# Altium schematic sheet UBX_NORA_B1_SCHEM_0002_Power.SchDoc
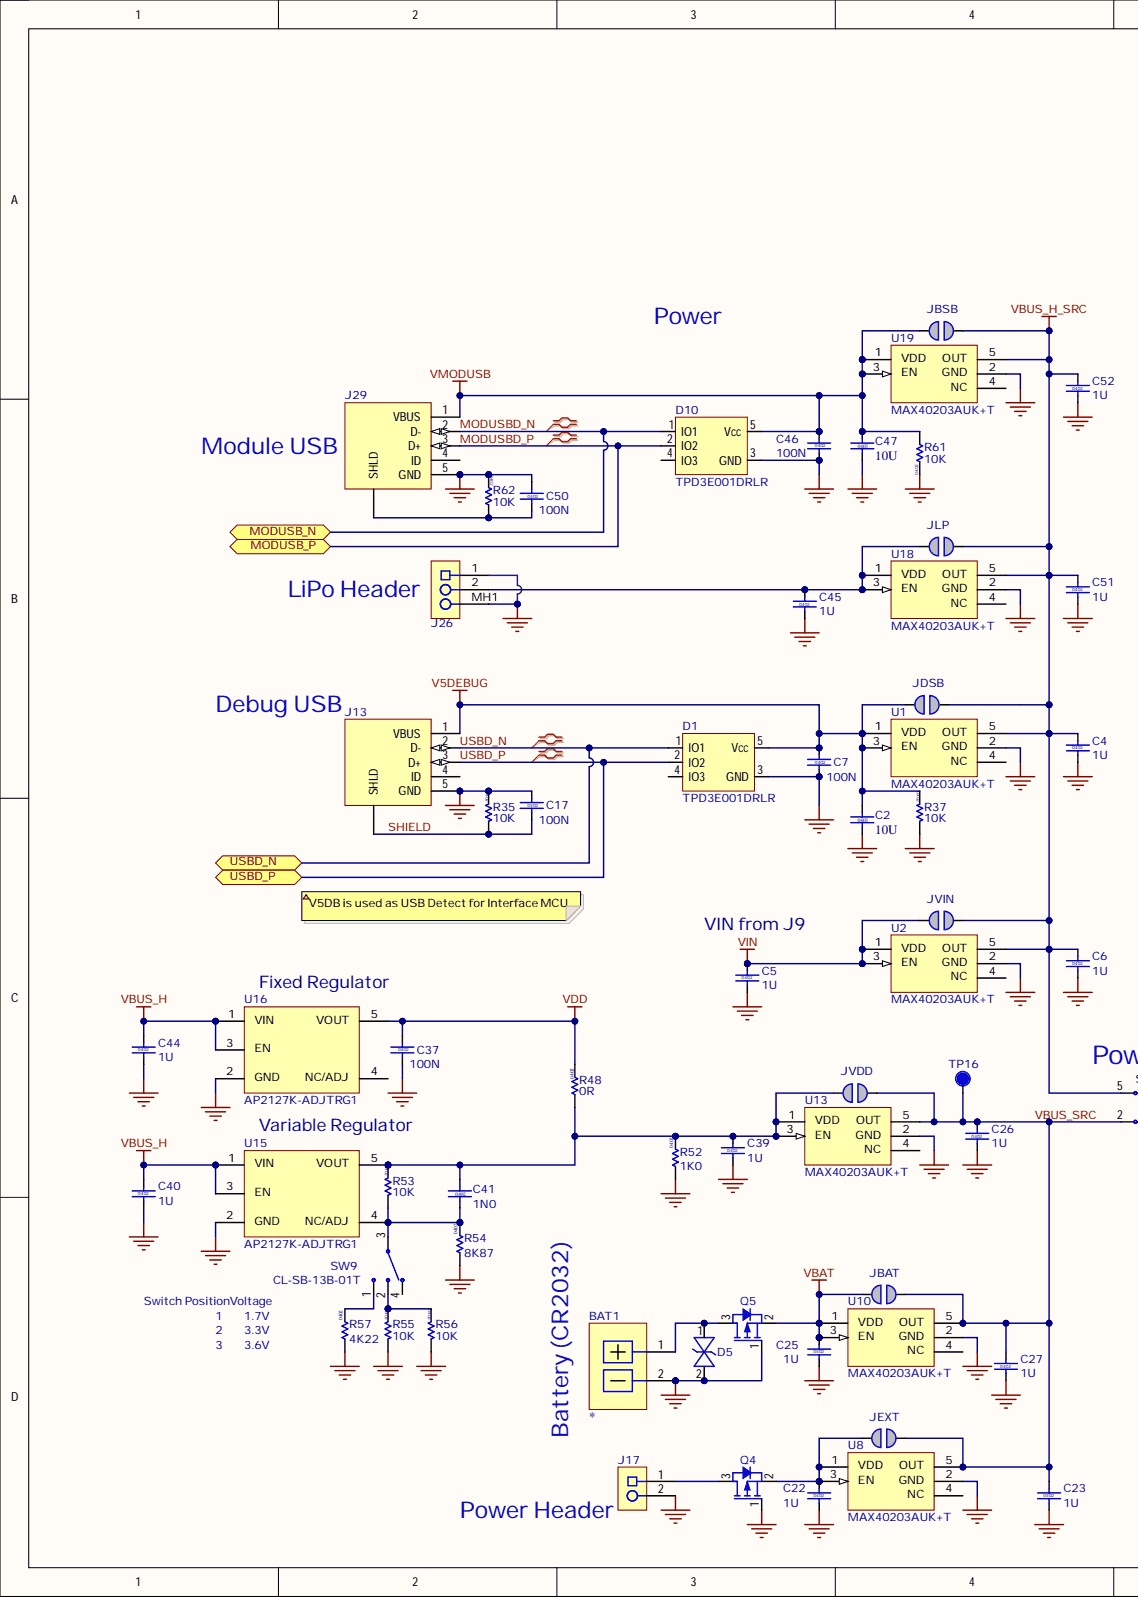
[diagram p0: UBX_NORA_B1_SCHEM_0002_Power.SchDoc, left]
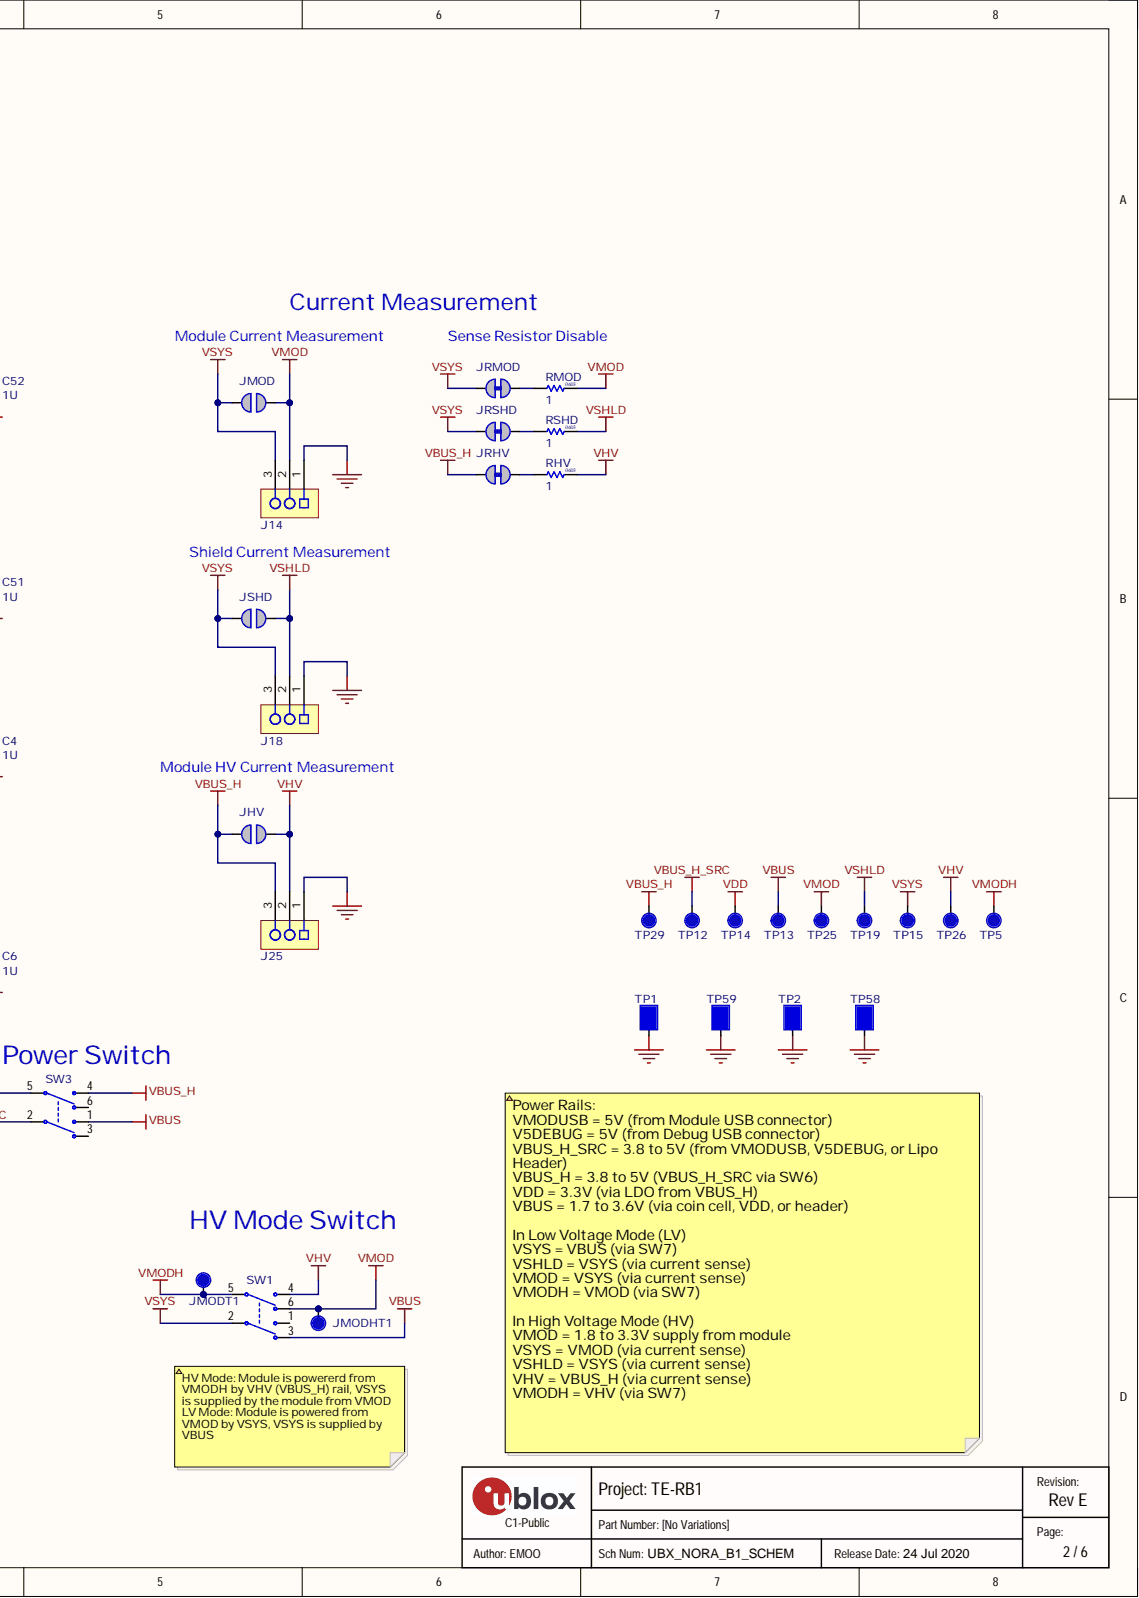
[diagram p1: UBX_NORA_B1_SCHEM_0002_Power.SchDoc, right]

## Parts
BAT1  Part Type: Battery  [Battery_S8211-46R_large]  (hidden comment: UBXH03-0000010)
C2  value 10U  Size: 0603  [CAP 0603 HD]  (hidden comment: UBXH01-000004010U)
C4  value 1U  Size: 0402  [Cap 0402 HD]  (hidden comment: UBXH01-00002481U)
C5  value 1U  Size: 0402  [Cap 0402 HD]  (hidden comment: UBXH01-00002481U)
C6  value 1U  Size: 0402  [Cap 0402 HD]  (hidden comment: UBXH01-00002481U)
C7  value 100N  Size: 0402  [Cap 0402 HD]  (hidden comment: UBXH01-2021002100N)
C17  value 100N  Size: 0402  [Cap 0402 HD]  (hidden comment: UBXH01-2021002100N)
C22  value 1U  Size: 0402  [Cap 0402 HD]  (hidden comment: UBXH01-00002481U)
C23  value 1U  Size: 0402  [Cap 0402 HD]  (hidden comment: UBXH01-00002481U)
C25  value 1U  Size: 0402  [Cap 0402 HD]  (hidden comment: UBXH01-00002481U)
C26  value 1U  Size: 0402  [Cap 0402 HD]  (hidden comment: UBXH01-00002481U)
C27  value 1U  Size: 0402  [Cap 0402 HD]  (hidden comment: UBXH01-00002481U)
C37  value 100N  Size: 0402  [Cap 0402 HD]  (hidden comment: UBXH01-2021002100N)
C39  value 1U  Size: 0402  [Cap 0402 HD]  (hidden comment: UBXH01-00002481U)
C40  value 1U  Size: 0402  [Cap 0402 HD]  (hidden comment: UBXH01-00002481U)
C41  value 1N0  Size: 0402  [Cap 0402 HD]  (hidden comment: UBXH01-00000131N0)
C44  value 1U  Size: 0402  [Cap 0402 HD]  (hidden comment: UBXH01-00002481U)
C45  value 1U  Size: 0402  [Cap 0402 HD]  (hidden comment: UBXH01-00002481U)
C46  value 100N  Size: 0402  [Cap 0402 HD]  (hidden comment: UBXH01-2021002100N)
C47  value 10U  Size: 0603  [CAP 0603 HD]  (hidden comment: UBXH01-000004010U)
C50  value 100N  Size: 0402  [Cap 0402 HD]  (hidden comment: UBXH01-2021002100N)
C51  value 1U  Size: 0402  [Cap 0402 HD]  (hidden comment: UBXH01-00002481U)
C52  value 1U  Size: 0402  [Cap 0402 HD]  (hidden comment: UBXH01-00002481U)
D1  value TPD3E001DRLR  Manufacturer P/N: TPD3E001DRLR  [SOT-553]  (hidden comment: UBXH07-0000086)
D5  UBXH07-0000034  [SOD-923]  (value not drawn)
D10  value TPD3E001DRLR  Manufacturer P/N: TPD3E001DRLR  [SOT-553]  (hidden comment: UBXH07-0000086)
J13  UBXH04-0000804  [USB_MICRO_RA_W-2TABS]  (value not drawn)
J14  UBXH04-0000792  [HDR-0.1P-3Pin-1Row-SMT]  (value not drawn)
J17  UBXH04-0000026  [HDR-0.1P-2PIN-TH]  (value not drawn)
J18  UBXH04-0000792  [HDR-0.1P-3Pin-1Row-SMT]  (value not drawn)
J25  UBXH04-0000792  [HDR-0.1P-3Pin-1Row-SMT]  (value not drawn)
J26  UBXH04-0000791  [B2B-PH-SM4-TB]  (value not drawn)
J29  UBXH04-0000804  [USB_MICRO_RA_W-2TABS]  (value not drawn)
JBAT  UBXH00-0000082  [Solderbridge-Open]  (value not drawn)  (no BOM)
JBSB  UBXH00-0000082  [Solderbridge-Open]  (value not drawn)  (no BOM)
JDSB  UBXH00-0000082  [Solderbridge-Open]  (value not drawn)  (no BOM)
JEXT  UBXH00-0000082  [Solderbridge-Open]  (value not drawn)  (no BOM)
JHV  UBXH00-0000082  [Solderbridge-Open]  (value not drawn)  (no BOM)
JLP  UBXH00-0000082  [Solderbridge-Open]  (value not drawn)  (no BOM)
JMOD  UBXH00-0000082  [Solderbridge-Open]  (value not drawn)  (no BOM)
JMODHT1  TP  [TP10R]  (value not drawn)  (no BOM)
JMODT1  TP  [TP10R]  (value not drawn)  (no BOM)
JRHV  UBXH00-0000084  [Solderbridge-Short]  (value not drawn)
JRMOD  UBXH00-0000084  [Solderbridge-Short]  (value not drawn)
JRSHD  UBXH00-0000084  [Solderbridge-Short]  (value not drawn)
JSHD  UBXH00-0000082  [Solderbridge-Open]  (value not drawn)  (no BOM)
JVDD  UBXH00-0000082  [Solderbridge-Open]  (value not drawn)  (no BOM)
JVIN  UBXH00-0000082  [Solderbridge-Open]  (value not drawn)  (no BOM)
Q4  UBXH20-0000087  [SOT-523]  (value not drawn)
Q5  UBXH20-0000087  [SOT-523]  (value not drawn)
R35  value 10K  Size: 0402  [RES 0402 HD]  (hidden comment: UBXH18-000006510K)
R37  value 10K  Size: 0402  [RES 0402 HD]  (hidden comment: UBXH18-000006510K)
R48  value 0R  Size: 0402  [RES 0402 HD]  (hidden comment: UBXH18-00030020R)
R52  value 1K0  Size: 0402  [RES 0402 HD]  (hidden comment: UBXH18-00080491K0)
R53  value 10K  Size: 0402  [RES 0402 HD]  (hidden comment: UBXH18-000006510K)
R54  value 8K87  Size: 0402  [RES 0402 HD]  (hidden comment: UBXH18-00005688K87)
R55  value 10K  Size: 0402  [RES 0402 HD]  (hidden comment: UBXH18-000006510K)
R56  value 10K  Size: 0402  [RES 0402 HD]  (hidden comment: UBXH18-000006510K)
R57  value 4K22  Size: 0402  [RES 0402 HD]  (hidden comment: UBXH18-00005694K22)
R61  value 10K  Size: 0402  [RES 0402 HD]  (hidden comment: UBXH18-000006510K)
R62  value 10K  Size: 0402  [RES 0402 HD]  (hidden comment: UBXH18-000006510K)
RHV  value 1  Size: 0603  [RES_0603_1700Lx950Wx600H_600x1000Pad_800G_FLX]  (hidden comment: UBXH18-00005551)
RMOD  value 1  Size: 0603  [RES_0603_1700Lx950Wx600H_600x1000Pad_800G_FLX]  (hidden comment: UBXH18-00005551)
RSHD  value 1  Size: 0603  [RES_0603_1700Lx950Wx600H_600x1000Pad_800G_FLX]  (hidden comment: UBXH18-00005551)
SW1  UBXH19-0000050  [SW_Slide_DPDT_JS202011SCQN]  (value not drawn)
SW3  Part Type: Switch  [SW_Slide_DPDT_JS202011SCQN]  (hidden comment: UBXH19-0000050)
SW9  value CL-SB-13B-01T  [CL-SB-13B-01T]  (hidden comment: UBXH19-0000039)
TP1  UBXH00-0000097  [TP4.5x3Sq]  (value not drawn)  (no BOM)
TP2  UBXH00-0000097  [TP4.5x3Sq]  (value not drawn)  (no BOM)
TP5  TP  [TP12R]  (no BOM)
TP12  TP  [TP12R]  (no BOM)
TP13  TP  [TP12R]  (no BOM)
TP14  TP  [TP12R]  (no BOM)
TP15  TP  [TP12R]
TP16  TP  [TP10R]  (no BOM)
TP19  TP  [TP12R]  (no BOM)
TP25  TP  [TP12R]  (no BOM)
TP26  TP  [TP12R]  (no BOM)
TP29  TP  [TP12R]  (no BOM)
TP58  UBXH00-0000097  [TP4.5x3Sq]  (value not drawn)  (no BOM)
TP59  UBXH00-0000097  [TP4.5x3Sq]  (value not drawn)  (no BOM)
U1  value MAX40203AUK+T  Manufacturer P/N: MAX40203AUK+T  [SOT-23-5_2]  (hidden comment: UBXH12-0000539)
U2  value MAX40203AUK+T  Manufacturer P/N: MAX40203AUK+T  [SOT-23-5_2]  (hidden comment: UBXH12-0000539)
U8  value MAX40203AUK+T  Manufacturer P/N: MAX40203AUK+T  [SOT-23-5_2]  (hidden comment: UBXH12-0000539)
U10  value MAX40203AUK+T  Manufacturer P/N: MAX40203AUK+T  [SOT-23-5_2]  (hidden comment: UBXH12-0000539)
U13  value MAX40203AUK+T  Manufacturer P/N: MAX40203AUK+T  [SOT-23-5_2]  (hidden comment: UBXH12-0000539)
U15  value AP2127K-ADJTRG1  Manufacturer P/N: AP2127K-ADJTRG1  Part Type: Regulator  [SOT-23-5_3D_Body_Fix]  (hidden comment: UBXH12-0000547)
U16  Manufacturer Part Number 1: AP2127K-ADJTRG1  Part Type: Regulator  [SOT-23-5_3D_Body_Fix]  (hidden comment: UBXH12-0000546)
U18  value MAX40203AUK+T  Manufacturer P/N: MAX40203AUK+T  [SOT-23-5_2]  (hidden comment: UBXH12-0000539)
U19  value MAX40203AUK+T  Manufacturer P/N: MAX40203AUK+T  [SOT-23-5_2]  (hidden comment: UBXH12-0000539)

## Ports
MODUSB_N, MODUSB_P, USBD_N, USBD_P

## Nets
GND: BAT1.2 C2.2 C4.2 C5.2 C6.2 C7.2 C17.1 C22.2 C23.2 C25.2 C26.2 C27.2 C37.2 C39.2 C40.2 C44.2 C45.2 C46.2 C47.2 C50.1 C51.2 C52.2 D1.3(GND) D5.2 D10.3(GND) J13.5(GND) J14.1 J17.2 J18.1 J25.1 J26.1 J26.MH1 J29.5(GND) Q4.1 Q5.1 R35.2 R37.1 R52.1 R54.1 R55.1 R56.1 R57.1 R61.2 R62.2 TP1.1 TP2.1 TP58.1 TP59.1 U1.2(GND) U2.2(GND) U8.2(GND) U10.2(GND) U13.2(GND) U15.2(GND) U16.2(GND) U18.2(GND) U19.2(GND)
V5DEBUG: C2.1 C7.1 D1.5(Vcc) J13.1(VBUS) JDSB.2 R37.2 U1.1(VDD) U1.3(EN)
VBAT: C25.1 JBAT.2 Q5.2 U10.1(VDD) U10.3(EN)
VBUS: SW1.3 SW3.1 TP13.1
VBUS_H: C40.1 C44.1 J25.3 JHV.2 JRHV.2 SW3.4 TP29.1 U15.1(VIN) U15.3(EN) U16.1(VIN) U16.3(EN)
VBUS_H_SRC: C4.1 C6.1 C51.1 C52.1 JBSB.1 JDSB.1 JLP.1 JVIN.1 SW3.5 TP12.1 U1.5(OUT) U2.5(OUT) U18.5(OUT) U19.5(OUT)
VDD: C37.1 R48.2 TP14.1 U16.5(VOUT)
VHV: J25.2 JHV.1 RHV.2 SW1.4 TP26.1
VIN: C5.1 JVIN.2 U2.1(VDD) U2.3(EN)
VMOD: J14.2 JMOD.1 JMODHT1.1 RMOD.2 SW1.6 TP25.1
VMODH: JMODT1.1 SW1.5 TP5.1
VMODUSB: C46.1 C47.1 D10.5(Vcc) J29.1(VBUS) JBSB.2 R61.1 U19.1(VDD) U19.3(EN)
VSHLD: J18.2 JSHD.1 RSHD.2 TP19.1
VSYS: J14.3 J18.3 JMOD.2 JRMOD.2 JRSHD.2 JSHD.2 SW1.2 TP15.1
MODUSBD_N: D10.1(IO1) J29.2(D-) ; port MODUSB_N
MODUSBD_P: D10.2(IO2) J29.3(D+) ; port MODUSB_P
SHIELD: C17.2 J13.6(SHLD) R35.1
USBD_N: D1.1(IO1) J13.2(D-) ; port USBD_N
USBD_P: D1.2(IO2) J13.3(D+) ; port USBD_P
VBUS_SRC: C23.1 C26.1 C27.1 JBAT.1 JEXT.1 JVDD.1 SW3.2 TP16.1 U8.5(OUT) U10.5(OUT) U13.5(OUT)
NetBAT1_1: BAT1.1 D5.1 Q5.3
NetC22_1: C22.1 JEXT.2 Q4.2 U8.1(VDD) U8.3(EN)
NetC39_1: C39.1 C41.1 JVDD.2 R48.1 R52.2 R53.2 U13.1(VDD) U13.3(EN) U15.5(VOUT)
NetC41_2: C41.2 R53.1 R54.2 SW9.3 U15.4(NC/ADJ)
NetC45_1: C45.1 J26.2 JLP.2 U18.1(VDD) U18.3(EN)
NetC50_2: C50.2 J29.6(SHLD) R62.1
NetJ17_1: J17.1 Q4.3
NetJRHV_1: JRHV.1 RHV.1
NetJRMOD_1: JRMOD.1 RMOD.1
NetJRSHD_1: JRSHD.1 RSHD.1
NetR55_2: R55.2 R56.2 SW9.2
NetR57_2: R57.2 SW9.1

Not connected: D1 4(IO3); D10 4(IO3); J13 4(ID); J29 4(ID); SW1 1; SW3 3 6; SW9 4; U1 4(NC); U2 4(NC); U8 4(NC); U10 4(NC); U13 4(NC); U16 4(NC/ADJ); U18 4(NC); U19 4(NC)

## Library data of the parts
BAT1 = UBXH03-0000010: BATTERY HOLDER COIN SMT CR2016 CR2020 CR2025 CR2032 HARWIN S8211-46R; Database Table Name: Electromechanical - Battery; Editor: eric.moog; Env: ROHS; Perferred: PREF; Permitted Use: Support Products; Component Kind: Standard; Library Name: UBX_Parts_DB_Lib.DbLib; Max Operating Temp: 105°C; Min Operating Temp: -40°C; Part Number: UBXH03-0000010; RefDes: BT; UBX Wiki: https://wiki.u-blox.com/bin/view/Operations/PartDetails?index=38906
C2 C47 = UBXH01-0000040: CAP CER X5R 0603 10U 20% 10V; Manufacturer: Taiyo Yuden Company, Ltd.; Manufacturer P/N: LMK107BBJ106MALT; Database Table Name: Passive - Capacitor; Editor: pierpaolo.arban; Env: ROHS; Mfg P/N Released: Yes; Mfg P/N Split: 100; Mfg P/N Status: Active; Perferred: PREF; Permitted Use: Volume Products; Released by: matej.budin; Component Kind: Standard; Library Name: UBX_Parts_DB_Lib.DbLib; Manufacturer 2: Murata Manufacturing Company, Ltd.; Manufacturer 3: Taiyo Yuden Company, Ltd.; Manufacturer P/N 2: GRM188R61A106KAAL; Manufacturer P/N 3: LMK107BJ106MALTD; Max Voltage: 10V; Mfg P/N Datasheet: https://wiki.u-blox.com/bin/view/Generic/Docs/DocumentSummary?index=16905; Mfg P/N Datasheet 2: https://wiki.u-blox.com/bin/view/Generic/Docs/DocumentSummary?index=27232; Mfg P/N Datasheet 3: https://wiki.u-blox.com/bin/view/Generic/Docs/DocumentSummary?index=29392; Mfg P/N Released 2: Yes; Mfg P/N Released 3: Yes; Mfg P/N Split 2: 0; Mfg P/N Split 3: 0; Mfg P/N Status 2: Active; Mfg P/N Status 3: NRND; Part Number: UBXH01-0000040; Part Type: Capacitor; RefDes: C; Release Data: 2013-01-10T11:54:39; Signal Integrity: Cap Semi; Simulation: CAP; Tolerance: 20%; UBX Wiki: https://wiki.u-blox.com/bin/view/Operations/PartDetails?index=16909
C4-C6 C22 C23 C25-C27 C39 C40 C44 C45 C51 C52 = UBXH01-0000248: CAP CER X5R 0402 1U 10% 10V; Manufacturer: Murata Manufacturing Company, Ltd.; Manufacturer P/N: GRM155R61C105KA12; Database Table Name: Passive - Capacitor; Editor: michael.hein; Env: ROHS; Mfg P/N Released: Yes; Mfg P/N Split: 100; Mfg P/N Status: Active; Perferred: PREF; Permitted Use: Volume Products; Released by: igor.shevchenko; Component Kind: Standard; Library Name: UBX_Parts_DB_Lib.DbLib; Manufacturer 2: TDK Corporation; Manufacturer 3: Samsung Electro-Mechanics; Manufacturer P/N 2: C1005X5R1E105K050BC; Manufacturer P/N 3: CL05A105KA5NQNC; Max Operating Temp: 85°C; Max Voltage: 10V; Mfg P/N Datasheet: https://wiki.u-blox.com/bin/view/Generic/Docs/DocumentSummary?index=29581; Mfg P/N Datasheet 2: https://wiki.u-blox.com/bin/view/Generic/Docs/DocumentSummary?index=28058; Mfg P/N Datasheet 3: https://wiki.u-blox.com/bin/view/Generic/Docs/DocumentSummary?index=28056; Mfg P/N Released 2: Yes; Mfg P/N Released 3: Yes; Mfg P/N Split 2: 0; Mfg P/N Split 3: 0; Mfg P/N Status 2: Active; Mfg P/N Status 3: Active; Min Operating Temp: -40°C; Part Number: UBXH01-0000248; Part Type: Capacitor; RefDes: C; Release Data: 2016-03-16T11:26:35; Signal Integrity: Cap Semi; Simulation: CAP; Tolerance: 10%; UBX Wiki: https://wiki.u-blox.com/bin/view/Operations/PartDetails?index=24378
C7 C17 C37 C46 C50 = UBXH01-2021002: CAP CER X5R 0402 100N 10% 10V; Manufacturer: Murata Manufacturing Company, Ltd.; Manufacturer P/N: GRM155R61A104KA01; Database Table Name: Passive - Capacitor; Editor: roland.rust; Env: GREEN; Mfg P/N Released: Yes; Mfg P/N Split: 100; Mfg P/N Status: NRND; Perferred: PREF; Permitted Use: Volume Products; Component Kind: Standard; Library Name: UBX_Parts_DB_Lib.DbLib; Manufacturer 2: Samsung Electro-Mechanics; Manufacturer P/N 2: CL05A104KP5NNN; Max Voltage: 10V; Mfg P/N Datasheet 2: https://wiki.u-blox.com/bin/view/Generic/Docs/DocumentSummary?index=28113; Mfg P/N Released 2: Yes; Mfg P/N Split 2: 0; Mfg P/N Status 2: Active; Part Number: UBXH01-2021002; Part Type: Capacitor; RefDes: C; Release Data: 2005-07-22T13:59:44; Signal Integrity: Cap Semi; Simulation: CAP; Tolerance: 10%; UBX Wiki: https://wiki.u-blox.com/bin/view/Operations/PartDetails?index=5759; Released by: roland.rust
C41 = UBXH01-0000013: CAP CER X7R 0402 1N0 10% 50V; Manufacturer: Murata Manufacturing Company, Ltd.; Manufacturer P/N: GRM155R71H102KA01; Database Table Name: Passive - Capacitor; Editor: massimo.vlacci; Env: ROHS; Mfg P/N Released: Yes; Mfg P/N Split: 100; Mfg P/N Status: NRND; Perferred: PREF; Permitted Use: Volume Products; Released by: dario.antonaz; Component Kind: Standard; Library Name: UBX_Parts_DB_Lib.DbLib; Max Voltage: 50V; Mfg P/N Datasheet: https://wiki.u-blox.com/bin/view/Generic/Docs/DocumentSummary?index=22572; Part Number: UBXH01-0000013; Part Type: Capacitor; RefDes: C; Release Data: 2015-04-23T14:26:43; Signal Integrity: Cap Semi; Simulation: CAP; Tolerance: 10%; UBX Wiki: https://wiki.u-blox.com/bin/view/Operations/PartDetails?index=8466
D1 D10 = UBXH07-0000086: TVS DIODE 5.5VWM; Manufacturer: Texas Instruments; Database Table Name: Discrete - Diode; Editor: hozaifa.abdelgadir; Env: GREEN; Mfg P/N Released: No; Mfg P/N Split: 100; Mfg P/N Status: Active; Perferred: PREF; Permitted Use: Support Products; Component Kind: Standard; Library Name: UBX_Parts_DB_Lib.DbLib; Max Operating Temp: 85°C; Mfg P/N Datasheet: https://wiki.u-blox.com/bin/view/Generic/Docs/DocumentSummary?index=38197; Min Operating Temp: -40°C; Part Number: UBXH07-0000086; Part Type: Diode; RefDes: D; UBX Wiki: https://wiki.u-blox.com/bin/view/Operations/PartDetails?index=38374
D5 = UBXH07-0000034: ESD PROTECTION DIODE ULTRA LOW LEAKAGE SOD923 ON SEMI 3V3; Manufacturer: ON Semiconductor; Manufacturer P/N: ESD9R3.3ST5G; Database Table Name: Discrete - Diode; Editor: pierpaolo.arban; Env: ROHS; Mfg P/N Released: Yes; Mfg P/N Split: 100; Mfg P/N Status: Active; Perferred: PREF; Permitted Use: Support Products; Released by: dario.antonaz; Component Kind: Standard; Library Name: UBX_Parts_DB_Lib.DbLib; Mfg P/N Datasheet: https://wiki.u-blox.com/bin/view/Generic/Docs/DocumentSummary?index=22449; Part Number: UBXH07-0000034; Part Type: Diode; RefDes: D; Release Data: 2015-04-23T14:51:11; UBX Wiki: https://wiki.u-blox.com/bin/view/Operations/PartDetails?index=22447; Value: 3V3
J13 J29 = UBXH04-0000804: CONN RECEPTACLE USB MICRO B 5-PIN SMD TH RA 5.5V 1.8A; Manufacturer: Amphenol Commercial Products (Amphenol ICC); Manufacturer P/N: 10118193-0001LF; Database Table Name: Electromechanical - Connector; Editor: eric.moog; Env: ROHS; Mfg P/N Released: No; Mfg P/N Split: 100; Mfg P/N Status: Active; Permitted Use: Support Products; Value: 10118193-0001LF; Component Kind: Standard; Library Name: UBX_Parts_DB_Lib.DbLib; Max Current: 1.8A; Max Operating Temp: 85°C; Max Voltage: 5.5V; Mfg P/N Datasheet: https://wiki.u-blox.com/bin/view/Generic/Docs/DocumentSummary?index=38943; Min Operating Temp: -30°C; Part Number: UBXH04-0000804; Part Type: Connector; Perferred: N/A; RefDes: J; UBX Wiki: https://wiki.u-blox.com/bin/view/Operations/PartDetails?index=38944
J14 J18 J25 = UBXH04-0000792: 1-ROW SMD CONN 100MIL GRID 3 -PIN 100V 3A; Manufacturer: HARWiN; Manufacturer P/N: M20-8770342; Database Table Name: Electromechanical - Connector; Editor: eric.moog; Env: ROHS; Mfg P/N Released: No; Mfg P/N Split: 100; Mfg P/N Status: Active; Permitted Use: Support Products; Value: M20-8770342; Component Kind: Standard; Library Name: UBX_Parts_DB_Lib.DbLib; Max Current: 3A; Max Operating Temp: 105°C; Max Voltage: 100V; Mfg P/N Datasheet: https://wiki.u-blox.com/bin/view/Generic/Docs/DocumentSummary?index=28457; Min Operating Temp: -40°C; Part Number: UBXH04-0000792; Part Type: Connector; Perferred: N/A; RefDes: J; UBX Wiki: https://wiki.u-blox.com/bin/view/Operations/PartDetails?index=38569
J17 = UBXH04-0000026: 1-ROW TH-PCB SOCKETS 100MIL GRID 2-PIN 0.64MM SQUARE POLARIZE; Manufacturer: HARWiN; Manufacturer P/N: M20-9990245; Database Table Name: Electromechanical - Connector; Editor: roland.rust; Env: ROHS; Mfg P/N Released: Yes; Mfg P/N Split: 100; Mfg P/N Status: Active; Perferred: PREF; Permitted Use: Internal Use; Released by: alvaro.nussbaum; Value: M20-9990245; Component Kind: Standard; Library Name: UBX_Parts_DB_Lib.DbLib; Part Number: UBXH04-0000026; Part Type: Connector; RefDes: J; Release Data: 2015-06-04T16:45:09; UBX Wiki: https://wiki.u-blox.com/bin/view/Operations/PartDetails?index=10937
J26 = UBXH04-0000791: CONN 1-ROW SMT 2.0MM GRID 2-PIN VERT 100V 2A; Manufacturer: JST; Part Name: Conn, SMT, 0.079" Pitch, 2 Pin, Vert, Black; Database Table Name: Electromechanical - Connector; Editor: eric.moog; Env: ROHS; Item Phase: In Production; Mfg P/N Released: No; Mfg P/N Split: 100; Mfg P/N Status: Active; Permitted Use: Support Products; UBX Code: None; Use in new designs: Yes; Value: B2B-PH-SM4-TB; Component Kind: Standard; Diameter: None; Height: 6.5mm; Library Name: UBX_Parts_DB_Lib.DbLib; Manufacturer 2: None; Manufacturer P/N: B2B-PH-SM4-TB; Manufacturer Part Number 1: B2B-PH-SM4-TB; Manufacturer Part Number 2: None; Max Current: 2A; Max Operating Temp: 85°C; Max Voltage: 100V; Mfg P/N Datasheet: https://wiki.u-blox.com/bin/view/Generic/Docs/DocumentSummary?index=35463; Min Operating Temp: -30°C; Part Number: UBXH04-0000791; Part Type: Connector; Perferred: N/A; Pins: 2; RefDes: J; Revision: 0A; Supplier 1: None; Supplier 2: None; Supplier Part Number 1: None; Supplier Part Number 2: None; UBX Wiki: https://wiki.u-blox.com/bin/view/Operations/PartDetails?index=38567; Voltage: None
JBAT JBSB JDSB JEXT JHV JLP JMOD JSHD JVDD JVIN = UBXH00-0000082: SOLDERBRIDGE-OPEN; Database Table Name: Printed Circuit Element - Connector; Editor: aneeb.sohail; Env: GREEN; Perferred: PREF; Permitted Use: Volume Products; Library Name: UBX_Parts_DB_Lib.DbLib; Max Operating Temp: 155°C; Min Operating Temp: -55°C; Part Number: UBXH00-0000082; Part Type: Connector; RefDes: J; UBX Wiki: https://wiki.u-blox.com/bin/view/Operations/PartDetails?index=36361
JMODHT1 JMODT1 TP16 = UBXH00-0000096: TESTPOINT 1MM CIRCULAR; Database Table Name: Printed Circuit Element - Testpoint; Editor: eric.moog; Perferred: PREF; Permitted Use: Volume Products; Env: N/A; Library Name: UBX_Parts_DB_Lib.DbLib; Max Operating Temp: 125°C; Min Operating Temp: -55°C; Part Number: UBXH00-0000096; Part Type: Testpoint; RefDes: TP; UBX Wiki: https://wiki.u-blox.com/bin/view/Operations/PartDetails?index=38784
JRHV JRMOD JRSHD = UBXH00-0000084: SOLDERBRIDGE-SHORT; Database Table Name: Printed Circuit Element - Connector; Editor: aneeb.sohail; Env: GREEN; Perferred: PREF; Permitted Use: Volume Products; Component Kind: Net Tie; Library Name: UBX_Parts_DB_Lib.DbLib; Max Operating Temp: 155°C; Min Operating Temp: -55°C; Part Number: UBXH00-0000084; Part Type: Connector; RefDes: J; UBX Wiki: https://wiki.u-blox.com/bin/view/Operations/PartDetails?index=36363
Q4 Q5 = UBXH20-0000087: P-CHANNEL MOSFET DIODES SOT-523 DMG1013T-7 20V 460mA; Database Table Name: Discrete - Transistor; Editor: eric.moog; Env: ROHS; Perferred: PREF; Permitted Use: Volume Products; Component Kind: Standard; Library Name: UBX_Parts_DB_Lib.DbLib; Max Current: 460mA; Max Operating Temp: 155°C; Max Voltage: 20V; Min Operating Temp: -55°C; Part Number: UBXH20-0000087; Part Type: Transistor; RefDes: Q; UBX Wiki: https://wiki.u-blox.com/bin/view/Operations/PartDetails?index=38920
R35 R37 R53 R55 R56 R61 R62 = UBXH18-0000065: RES THICK FILM CHIP 0402 10K 1% 63mW; Manufacturer: KOA; Manufacturer P/N: RK73H1ETTP1002F; Database Table Name: Passive - Resistor; Editor: erian.bezhani; Env: ROHS; Mfg P/N Released: Yes; Mfg P/N Split: 100; Mfg P/N Status: Active; Perferred: PREF; Permitted Use: Volume Products; Released by: massimo.vlacci; Component Kind: Standard; Library Name: UBX_Parts_DB_Lib.DbLib; Max Power: 63mW; Mfg P/N Datasheet: https://wiki.u-blox.com/bin/view/Generic/Docs/DocumentSummary?index=8222; Part Number: UBXH18-0000065; Part Type: Resistor; RefDes: R; Release Data: 2012-06-08T18:02:21; Signal Integrity: Res; Simulation: RESISTOR; Tolerance: 1%; UBX Wiki: https://wiki.u-blox.com/bin/view/Operations/PartDetails?index=16837
R48 = UBXH18-0003002: RES THICK FILM CHIP 0402 0R 0 100mW; Manufacturer: KOA; Manufacturer P/N: RK73Z1ETTD; Database Table Name: Passive - Resistor; Editor: charlotte.biber; Env: ROHS; Mfg P/N Released: Yes; Mfg P/N Split: 100; Mfg P/N Status: NRND; Perferred: PREF; Permitted Use: Volume Products; Released by: peter.mueller; Tolerance: 0; Component Kind: Standard; Library Name: UBX_Parts_DB_Lib.DbLib; Manufacturer 2: YAGEO; Manufacturer P/N 2: 2322_705_91001_L; Max Power: 100mW; Mfg P/N Released 2: Yes; Mfg P/N Split 2: 0; Mfg P/N Status 2: NRND; Part Number: UBXH18-0003002; Part Type: Resistor; RefDes: R; Release Data: 2012-06-05T09:49:55; Signal Integrity: Res; Simulation: RESISTOR; UBX Wiki: https://wiki.u-blox.com/bin/view/Operations/PartDetails?index=6611
R52 = UBXH18-0008049: RES THICK FILM CHIP 0402 1K0 5% 100mW; Manufacturer: YAGEO; Manufacturer P/N: 2322_705_70102_L; Database Table Name: Passive - Resistor; Editor: roland.rust; Env: ROHS; Mfg P/N Released: Yes; Mfg P/N Split: 100; Mfg P/N Status: Obsolete; Perferred: PREF; Permitted Use: Volume Products; Component Kind: Standard; Library Name: UBX_Parts_DB_Lib.DbLib; Manufacturer 2: KOA; Manufacturer P/N 2: RK73B1ETTD102J; Max Power: 100mW; Mfg P/N Released 2: Yes; Mfg P/N Split 2: 0; Mfg P/N Status 2: NRND; Part Number: UBXH18-0008049; Part Type: Resistor; RefDes: R; Release Data: 2005-07-22T13:59:44; Signal Integrity: Res; Simulation: RESISTOR; Tolerance: 5%; UBX Wiki: https://wiki.u-blox.com/bin/view/Operations/PartDetails?index=6939; Released by: roland.rust
R54 = UBXH18-0000568: RES THICK FILM CHIP 0402 8K87 8K87 1% 63mW; Manufacturer: KOA; Manufacturer P/N: RK73H1ETTP8871F; Database Table Name: Passive - Resistor; Editor: eric.moog; Env: ROHS; Mfg P/N Released: No; Mfg P/N Split: 100; Mfg P/N Status: Active; Perferred: PREF; Permitted Use: Volume Products; Component Kind: Standard; Library Name: UBX_Parts_DB_Lib.DbLib; Max Operating Temp: 155°C; Max Power: 63mW; Mfg P/N Datasheet: https://wiki.u-blox.com/bin/view/Generic/Docs/DocumentSummary?index=8222; Min Operating Temp: -55°C; Part Number: UBXH18-0000568; Part Type: Resistor; RefDes: R; Signal Integrity: Res; Simulation: RESISTOR; Tolerance: 1%; UBX Wiki: https://wiki.u-blox.com/bin/view/Operations/PartDetails?index=38916
R57 = UBXH18-0000569: RES THICK FILM CHIP 0402 4K22 4K22 1% 63mW; Manufacturer: KOA; Manufacturer P/N: RK73H1ETTP4221F; Database Table Name: Passive - Resistor; Editor: eric.moog; Env: ROHS; Mfg P/N Released: No; Mfg P/N Split: 100; Mfg P/N Status: Active; Perferred: PREF; Permitted Use: Volume Products; Component Kind: Standard; Library Name: UBX_Parts_DB_Lib.DbLib; Max Operating Temp: 155°C; Max Power: 63mW; Mfg P/N Datasheet: https://wiki.u-blox.com/bin/view/Generic/Docs/DocumentSummary?index=8222; Min Operating Temp: -55°C; Part Number: UBXH18-0000569; Part Type: Resistor; RefDes: R; Signal Integrity: Res; Simulation: RESISTOR; Tolerance: 1%; UBX Wiki: https://wiki.u-blox.com/bin/view/Operations/PartDetails?index=38918
RHV RMOD RSHD = UBXH18-0000555: RES THICK FILM CHIP 0603 200PPM/C 0.1W 1 1% 100mW; Manufacturer: Bourns; Manufacturer P/N: CR0603-F/-1R00ELF; Database Table Name: Passive - Resistor; Editor: mikhail.sialitski; Env: ROHS; Mfg P/N Released: Yes; Mfg P/N Split: 100; Mfg P/N Status: Active; Permitted Use: Support Products; Released by: gianni.falleroni; Component Kind: Standard; Library Name: UBX_Parts_DB_Lib.DbLib; Max Operating Temp: 155°C; Max Power: 100mW; Mfg P/N Datasheet: https://wiki.u-blox.com/bin/view/Generic/Docs/DocumentSummary?index=37926; Min Operating Temp: -55°C; Part Number: UBXH18-0000555; Part Type: Resistor; Perferred: N/A; RefDes: R; Release Data: 2023-02-01T14:11:03; Signal Integrity: Res; Simulation: RESISTOR; Tolerance: 1%; UBX Wiki: https://wiki.u-blox.com/bin/view/Operations/PartDetails?index=37924
SW1 SW3 = UBXH19-0000050: 2 WAY ON-ON SUB-MINIATURE SLIDE SWITCH SMD JS SERIES; Manufacturer: C&K Components; Manufacturer P/N: JS202011SCQN; Database Table Name: Electromechanical - Switch; Editor: andre.pick; Env: ROHS; Mfg P/N Released: Yes; Mfg P/N Split: 100; Mfg P/N Status: Active; Perferred: PREF; Permitted Use: Support Products; Released by: martin.pilik; Value: JS202011SCQN; Component Kind: Standard; Library Name: UBX_Parts_DB_Lib.DbLib; Max Operating Temp: 85°C; Mfg P/N Datasheet: https://wiki.u-blox.com/bin/view/Generic/Docs/DocumentSummary?index=16625; Min Operating Temp: -40°C; Part Number: UBXH19-0000050; RefDes: S; Release Data: 2022-06-09T16:59:15; UBX Wiki: https://wiki.u-blox.com/bin/view/Operations/PartDetails?index=36899
  SW1: Part Type: Switch
SW9 = UBXH19-0000039: 3-WAY SLIDE SMD SWITCH SP3T; Manufacturer: Nidec Copal Electronics; Part Name: SWITCH SLIDE SP3T 300MA 6V SMD; Component Mounting Type: SMT; Current: 200mA; Database Table Name: Electromechanical - Switch; Editor: evgenii.platonov; Env: ROHS; Item_Phase: Preliminary; Mfg P/N Released: No; Mfg P/N Split: 100; Mfg P/N Status: Active; Perferred: PREF; Permitted Use: Support Products; Switch/Button Function: On-On-On; Use in new designs: Yes; Voltage: 12V; Color: Silver; Component Kind: Standard; Height: 5.5mm; Length: 12mm; Library Name: UBX_Parts_DB_Lib.DbLib; Manufacturer P/N: CL-SB-13B-01T; Max Operating Temp: 85°C; Mfg P/N Datasheet: https://wiki.u-blox.com/bin/view/Generic/Docs/DocumentSummary?index=33528; Min Operating Temp: -40°C; Part Number: UBXH19-0000039; Part Type: Switch; Part_Type: Switch; Pins: 4; RefDes: S; Supplier 1: Digi-Key; Supplier Part Number 1: 563-1330-2-ND; Switch/Button Circuit: SP3T; UBX Wiki: https://wiki.u-blox.com/bin/view/Operations/PartDetails?index=33526; Width: 3.5mm
TP1 TP2 TP58 TP59 = UBXH00-0000097: TESTPOINT 3MMX4.5MM RECTANGULAR NO SILKSCREEN; Database Table Name: Printed Circuit Element - Testpoint; Editor: eric.moog; Permitted Use: Volume Products; Env: N/A; Library Name: UBX_Parts_DB_Lib.DbLib; Max Operating Temp: 125°C; Min Operating Temp: -55°C; Part Number: UBXH00-0000097; Part Type: Testpoint; Perferred: N/A; RefDes: TP; UBX Wiki: https://wiki.u-blox.com/bin/view/Operations/PartDetails?index=38793
TP5 TP12-TP14 TP19 TP25 TP26 TP29 = UBXH00-0000102: TESTPOINT 1.2MM CIRCULAR W/ SILKSCREEN; Database Table Name: Printed Circuit Element - Testpoint; Editor: eric.moog; Perferred: PREF; Permitted Use: Support Products; Env: N/A; Library Name: UBX_Parts_DB_Lib.DbLib; Max Operating Temp: 155°C; Min Operating Temp: -55°C; Part Number: UBXH00-0000102; Part Type: Testpoint; RefDes: TP; UBX Wiki: https://wiki.u-blox.com/bin/view/Operations/PartDetails?index=38966
TP15 = UBXH00-0000102: TESTPOINT 1.2MM CIRCULAR; Database Table Name: Printed Circuit Element - Testpoint; Editor: eric.moog; Perferred: PREF; Permitted Use: Support Products; Component Kind: Standard; Env: N/A; Library Name: UBX_Parts_DB_Lib.DbLib; Max Operating Temp: 155°C; Min Operating Temp: -55°C; Part Number: UBXH00-0000102; Part Type: Testpoint; RefDes: TP; UBX Wiki: https://wiki.u-blox.com/bin/view/Operations/PartDetails?index=38966
U1 U2 U8 U10 U13 U18 U19 = UBXH12-0000539: IDEAL DIODE OR CONTROLLER SOURCE SELECTOR SWITCH P-CHANNEL 1:1 SOT-23-5 5.5V 1A; Manufacturer: Maxim; Part Name: IC IDEAL DIODE LDO 1.2-5.5V 1.0A SOT-23-5; Body Size: 2.8mm x 2.9mm; Component Mounting Type: SMT; Current: 1.0A; Database Table Name: IC - PMIC; Editor: eric.moog; Env: ROHS; Item_Phase: Preliminary; Mfg P/N Released: No; Mfg P/N Split: 100; Mfg P/N Status: Active; Permitted Use: Support Products; SMT Pitch: 0.95mm; Use in new designs: Yes; Component Kind: Standard; Height: 1.25; HelpURL: https://datasheets.maximintegrated.com/en/ds/MAX40203.pdf; Library Name: UBX_Parts_DB_Lib.DbLib; Manufacturer 1: Maxim; Manufacturer Part Number 1: MAX40203AUK+T; Max Current: 1A; Max Voltage: 5.5V; Mfg P/N Datasheet: https://wiki.u-blox.com/bin/view/Generic/Docs/DocumentSummary?index=38598; MFG Package: SOT-23-5; Package Type: SOT-23-5; Part Number: UBXH12-0000539; Part Type: PMIC; Part_Type: Ideal Diode; Perferred: N/A; Pins: 5; RefDes: U; Supplier 1: Digi-Key; Supplier Part Number 1: 175-MAX40203AUK+TTR-ND; UBX Wiki: https://wiki.u-blox.com/bin/view/Operations/PartDetails?index=38597; Voltage: 5.5V
U15 = UBXH12-0000547: LDO REGULATOR ADJ 3.3V 300MA AP2127 SOT-23-5 6V 300mA; Manufacturer: Diodes Incorporated; Part Name: IC REG LDO ADJ 0.3A SOT23-5; Part_Type: Power Supply; Body Size: 1.7mm x 3.1mm; Component Mounting Type: SMT; Database Table Name: IC - Regulator; Editor: eric.moog; Env: ROHS; Item_Phase: Preliminary; Mfg P/N Released: No; Mfg P/N Split: 100; Mfg P/N Status: Active; Perferred: PREF; Permitted Use: Support Products; Regulator Outputs: 1xLDO; SMT Pitch: 0.95mm; Use in new designs: Yes; Component Kind: Standard; Current: 300mA; Height: 1.45mm; Library Name: UBX_Parts_DB_Lib.DbLib; Manufacturer 1: Diodes Incorporated; Manufacturer Part Number 1: AP2127K-ADJTRG1; Max Current: 300mA; Max Operating Temp: 85°C; Max Voltage: 6V; Mfg P/N Datasheet: https://wiki.u-blox.com/bin/view/Generic/Docs/DocumentSummary?index=38901; MFG Package: SOT-23-5; Min Operating Temp: -40°C; Package Type: SOT; Part Number: UBXH12-0000547; Pins: 5; RefDes: U; Supplier 1: Digi-Key; Supplier Part Number 1: AP2127K-ADJTRG1DITR-ND; UBX Wiki: https://wiki.u-blox.com/bin/view/Operations/PartDetails?index=38903; Voltage: 6V
U16 = UBXH12-0000546: LDO REGULATOR FIX 3.3V 300MA AP2127 SOT-23-5 6V 300mA; Manufacturer: Diodes Incorporated; Manufacturer P/N: AP2127K-3.3TRG1; Part Name: IC REG LDO ADJ 0.3A SOT23-5; Part_Type: Power Supply; Body Size: 1.7mm x 3.1mm; Component Mounting Type: SMT; Database Table Name: IC - Regulator; Editor: eric.moog; Env: ROHS; Item_Phase: Preliminary; Mfg P/N Released: No; Mfg P/N Split: 100; Mfg P/N Status: Active; Perferred: PREF; Permitted Use: Support Products; Regulator Outputs: 1xLDO; SMT Pitch: 0.95mm; Use in new designs: Yes; Value: AP2127K-3.3TRG1; Component Kind: Standard; Current: 300mA; Height: 1.45mm; Library Name: UBX_Parts_DB_Lib.DbLib; Manufacturer 1: Diodes Incorporated; Max Current: 300mA; Max Operating Temp: 85°C; Max Voltage: 6V; Mfg P/N Datasheet: https://wiki.u-blox.com/bin/view/Generic/Docs/DocumentSummary?index=38901; MFG Package: SOT-23-5; Min Operating Temp: -40°C; Package Type: SOT; Part Number: UBXH12-0000546; Pins: 5; RefDes: U; Supplier 1: Digi-Key; Supplier Part Number 1: AP2127K-ADJTRG1DITR-ND; UBX Wiki: https://wiki.u-blox.com/bin/view/Operations/PartDetails?index=38900; Voltage: 6V
